# Altium schematic sheet Sheet1.SchDoc
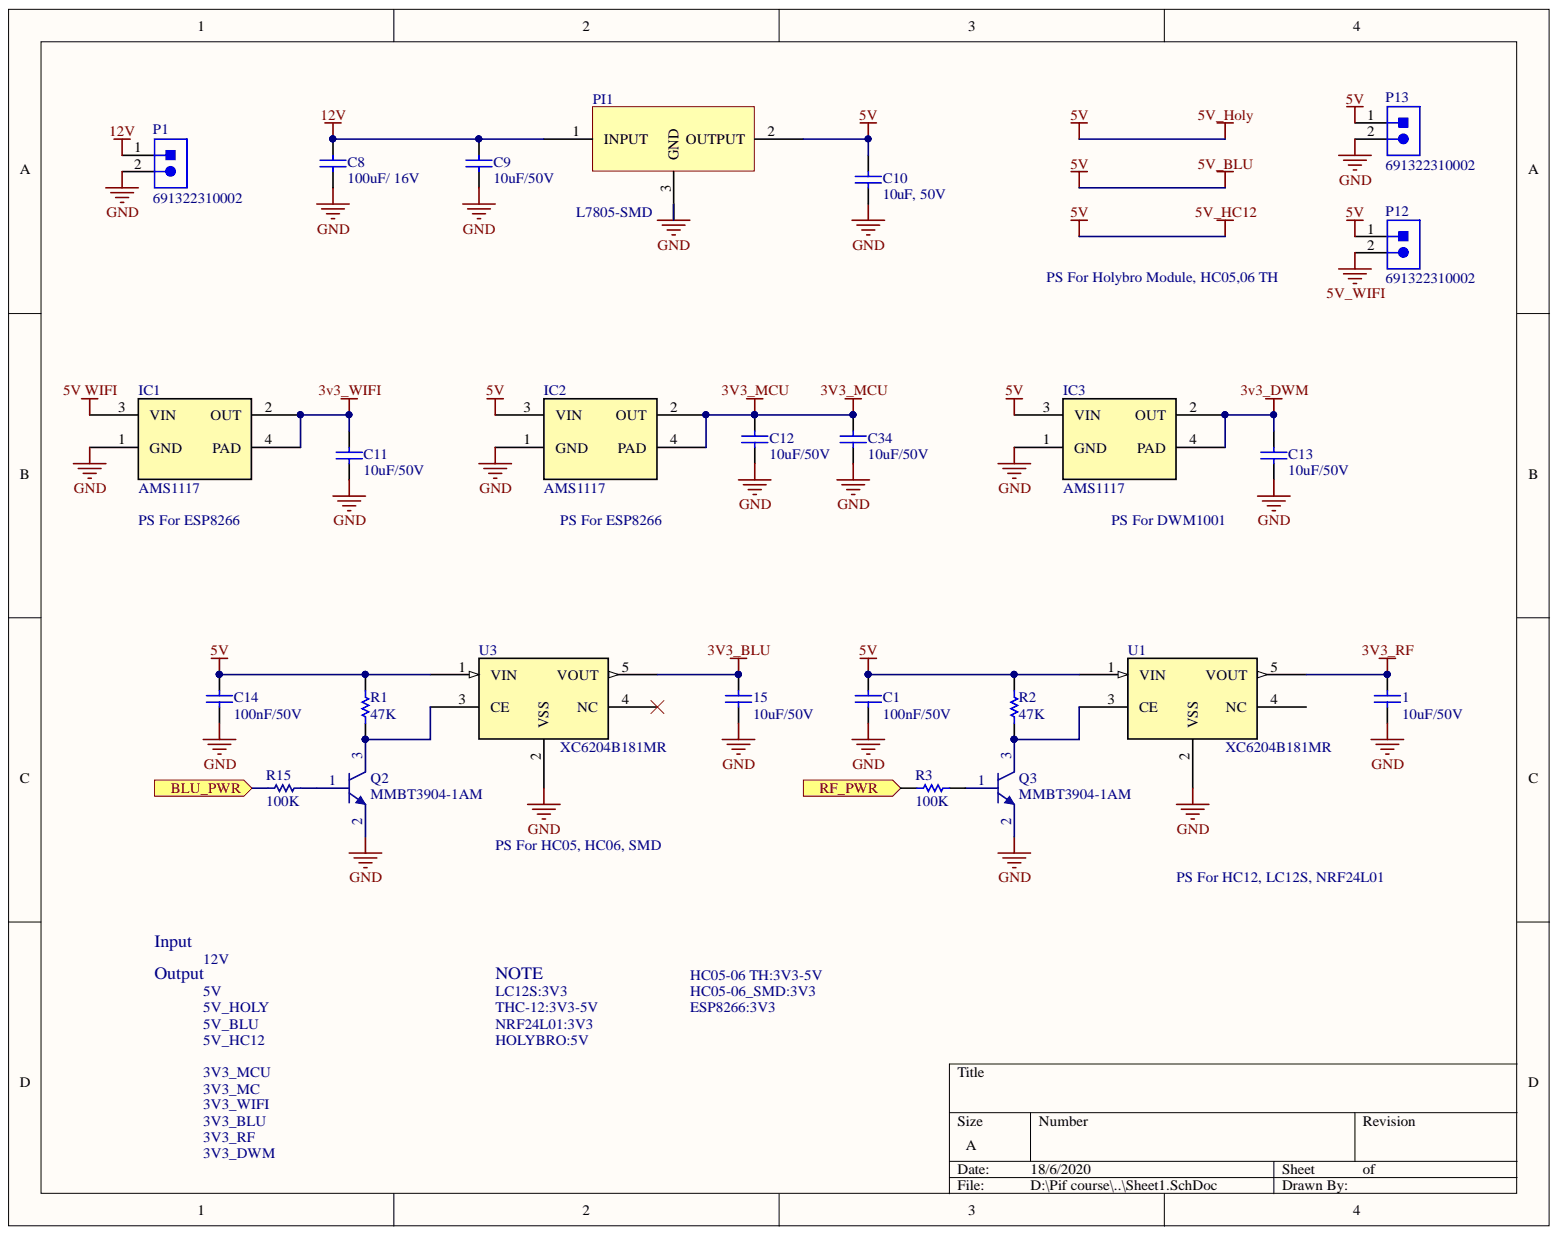
[diagram p0: Sheet1.SchDoc]

## Parts
1  10uF/50V  [WCAP-CSGP_0402_H=0.5mm]
15  10uF/50V  [WCAP-CSGP_0402_H=0.5mm]
C1  100nF/50V  [WCAP-CSGP_0402_H=0.5mm]
C8  100uF/ 16V  [WCAP-CSGP_0402_H=0.5mm]
C9  10uF/50V  [WCAP-CSGP_0402_H=0.5mm]
C10  10uF, 50V  [WCAP-CSGP_0402_H=0.5mm]
C11  10uF/50V  [WCAP-CSGP_0402_H=0.5mm]
C12  10uF/50V  [WCAP-CSGP_0402_H=0.5mm]
C13  10uF/50V  [WCAP-CSGP_0402_H=0.5mm]
C14  100nF/50V  [WCAP-CSGP_0402_H=0.5mm]
C34  10uF/50V  [WCAP-CSGP_0402_H=0.5mm]
IC1  AMS1117  [AMS1117]
IC2  AMS1117  [AMS1117]
IC3  AMS1117  [AMS1117]
P1  691322310002  [691322310002]
P12  691322310002  [691322310002]
P13  691322310002  [691322310002]
PI1  L7805-SMD  [L7805-SMD]
Q2  MMBT3904-1AM  [SOT23_NEX]
Q3  MMBT3904-1AM  [SOT23_NEX]
R1  value 47K  [RES-SM-0805]  (hidden comment: Res-1K-0805)
R2  value 47K  [RES-SM-0805]  (hidden comment: Res-1K-0805)
R3  value 100K  [RES-SM-0805]  (hidden comment: Res-1K-0805)
R15  value 100K  [RES 1/4W]  (hidden comment: Resistor 1/4W)
U1  XC6204B181MR  [XC6204B332MR]
U3  XC6204B181MR  [XC6204B332MR]

## Ports
BLU_PWR, RF_PWR

## Nets
3V3_BLU: 15.2 U3.5(VOUT)
3v3_DWM: C13.2 IC3.2(OUT) IC3.4(PAD)
3V3_MCU: C12.2 C34.2 IC2.2(OUT) IC2.4(PAD)
3V3_RF: 1.2 U1.5(VOUT)
3v3_WIFI: C11.2 IC1.2(OUT) IC1.4(PAD)
5V: C1.2 C10.2 C14.2 IC2.3(VIN) IC3.3(VIN) P12.1 P13.1 PI1.2 R1.2 R2.2 U1.1(VIN) U3.1(VIN)
5V WIFI: IC1.3(VIN)
5V_WIFI: P12.2
12V: C8.2 C9.2 P1.1 PI1.1
GND: 1.1 15.1 C1.1 C8.1 C9.1 C10.1 C11.1 C12.1 C13.1 C14.1 C34.1 IC1.1(GND) IC2.1(GND) IC3.1(GND) P1.2 P13.2 PI1.3 Q2.2 Q3.2 U1.2(VSS) U3.2(VSS)
BLU_PWR: R15.2 ; port BLU_PWR
RF_PWR: R3.2 ; port RF_PWR
NetQ2_1: Q2.1 R15.1
NetQ2_3: Q2.3 R1.1 U3.3(CE)
NetQ3_1: Q3.1 R3.1
NetQ3_3: Q3.3 R2.1 U1.3(CE)

Not connected: U1 4(NC); U3 4(NC)

## Library data of the parts
1 15 C1 C11-C14 C34 = 885012005001: WCAP-CSGP Multilayer Ceramic Chip Capacitor, General Purpose, size 0402, NP0, 1pF, 10V; Manufacturer: Wurth Elektronik; Capacitance Tolerance: +/-0.5pF; Dissipation Factor: Q>=400+20C; Isolation Resistance: >=10GOhm; Rated Voltage: 10VDC; Technical Reference: NP004021R0D010DFCT10000; Capacitance: 1pF; Ceramic Type: NP0; Component Kind: Standard; ComponentLink1Description: Manufacturer; ComponentLink1URL: http://www.we-online.de; ComponentLink2Description: Datasheet; ComponentLink2URL: https://www.we-online.com/catalog/datasheet/885012005001.pdf; Height: 0.5mm; Library Name: 885012005049_Altium_WCAP-CSGP_rev20a.IntLib; Manufacturer Part Number: 885012005001; Mounting Technology: SMT; Operating Temperature: -55 to +125degC; Packaging: Tape and Reel; Published Date: May 2020; Size: 0402
C8-C10 = 885012005060: WCAP-CSGP Multilayer Ceramic Chip Capacitor, General Purpose, size 0402, NP0, 68pF, 50V; Manufacturer: Wurth Elektronik; Capacitance Tolerance: +/-5%; Dissipation Factor: Q>=1000; Isolation Resistance: >=10GOhm; Rated Voltage: 50VDC; Technical Reference: NP00402680J050DFCT10000; Capacitance: 68pF; Ceramic Type: NP0; Component Kind: Standard; ComponentLink1Description: Manufacturer; ComponentLink1URL: http://www.we-online.de; ComponentLink2Description: Datasheet; ComponentLink2URL: https://www.we-online.com/catalog/datasheet/885012005060.pdf; Height: 0.5mm; Library Name: 885012005049_Altium_WCAP-CSGP_rev20a.IntLib; Manufacturer Part Number: 885012005060; Mounting Technology: SMT; Operating Temperature: -55 to +125degC; Packaging: Tape and Reel; Published Date: May 2020; Size: 0402
IC1-IC3 = AMS1117: Built_By: EMA_Matt; SourceLibrary: Advanced_Monolithic_Systems_10-16-2019; Vendor: Advanced Monolithic Systems; Component Kind: Standard; Library Name: Integrated_Library.IntLib; Manufacturer_Part_Number: AMS1117; RefDes: RefDes; Type: DEV; Copyright: Copyright (C) 2018 Accelerated Designs. All rights reserved
P1 P12 P13 = 691322310002: WR-TBL Serie 322 Horizontal PCB Header, Pluggable, pitch 3.81mm, 2p; Compliance: RoHS; Modularity: No; Pin Number: 2; Rated Current [UL]: 10A; Working Voltage [UL]: 300VAC; Application: Pluggable; Component Kind: Standard; ComponentLink1Description: Manufacturer; ComponentLink1URL: http://www.we-online.de; ComponentLink2Description: Datasheet; ComponentLink2URL: https://katalog.we-online.de/em/datasheet/691322310002.pdf; Library Name: 691101710002_Altium_WR-TBL_rev19a.IntLib; Mounting Technology: THT; Operating Temperature: -40 to +105degC; Packaging: Box; Part Number: 691322310002; PCB/Cable/Panel: PCB; Pitch: 3.81mm; Published Date: October 2019; Type: Horizontal; Publisher: Wurth Elektronik
R1-R3 = Res-1K-0805: RES 1K OHM 1% 1/4W 0805; Manufacturer: Stackpole Electronics Inc; Manufacturer Part Number: RNCP0805FTD1K00; Component Kind: Standard; Library Name: Resistor.SchLib; Local Supplier: thegioiic; Local Supplier Link: https://thegioiic.com/products/dien-tro-1k-ohm-0805-5; Supplier 1: Digikey; Supplier 1 Link: https://www.digikey.com/product-detail/en/stackpole-electronics-inc/RNCP0805FTD1K00/RNCP0805FTD1K00CT-ND/2240568; Supplier 1 Part Number: RNCP0805FTD1K00CT-ND
R15 = RES: Component Kind: Standard; Library Name: PIF_C16_lib.IntLib
U1 U3 = XC6204B181MR: Built_By: EMA_UL_Team; SourceLibrary: Torex_2016-07-05_1; Vendor: Torex Semiconductor; Component Kind: Standard; Library Name: Integrated_Library.IntLib; Manufacturer_Part_Number: XC6204B181MR; RefDes: RefDes; Type: DEV; Copyright: Copyright (C) 2018 Accelerated Designs. All rights reserved
PI1 = L7805-SMD: Component Kind: Standard; Library Name: Integrated_Library.IntLib
Q2 Q3 = MMBT3904-1AM: Component Kind: Standard; Library Name: BJT.SchLib
